# Altium schematic sheet Sheet2.SchDoc
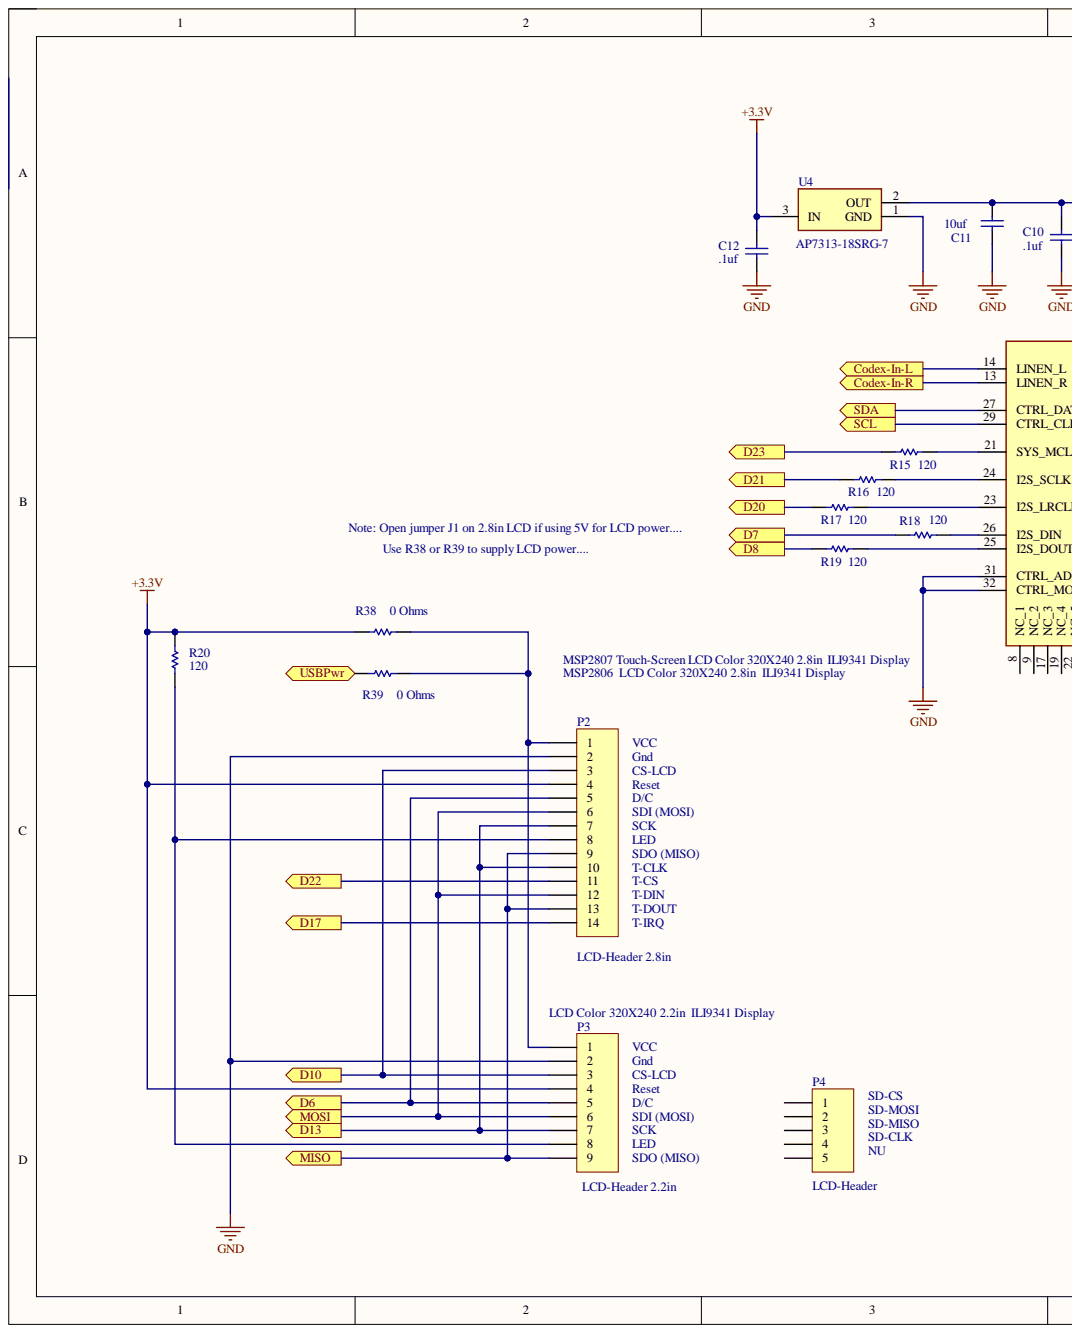
[diagram p0: Sheet2.SchDoc, left]
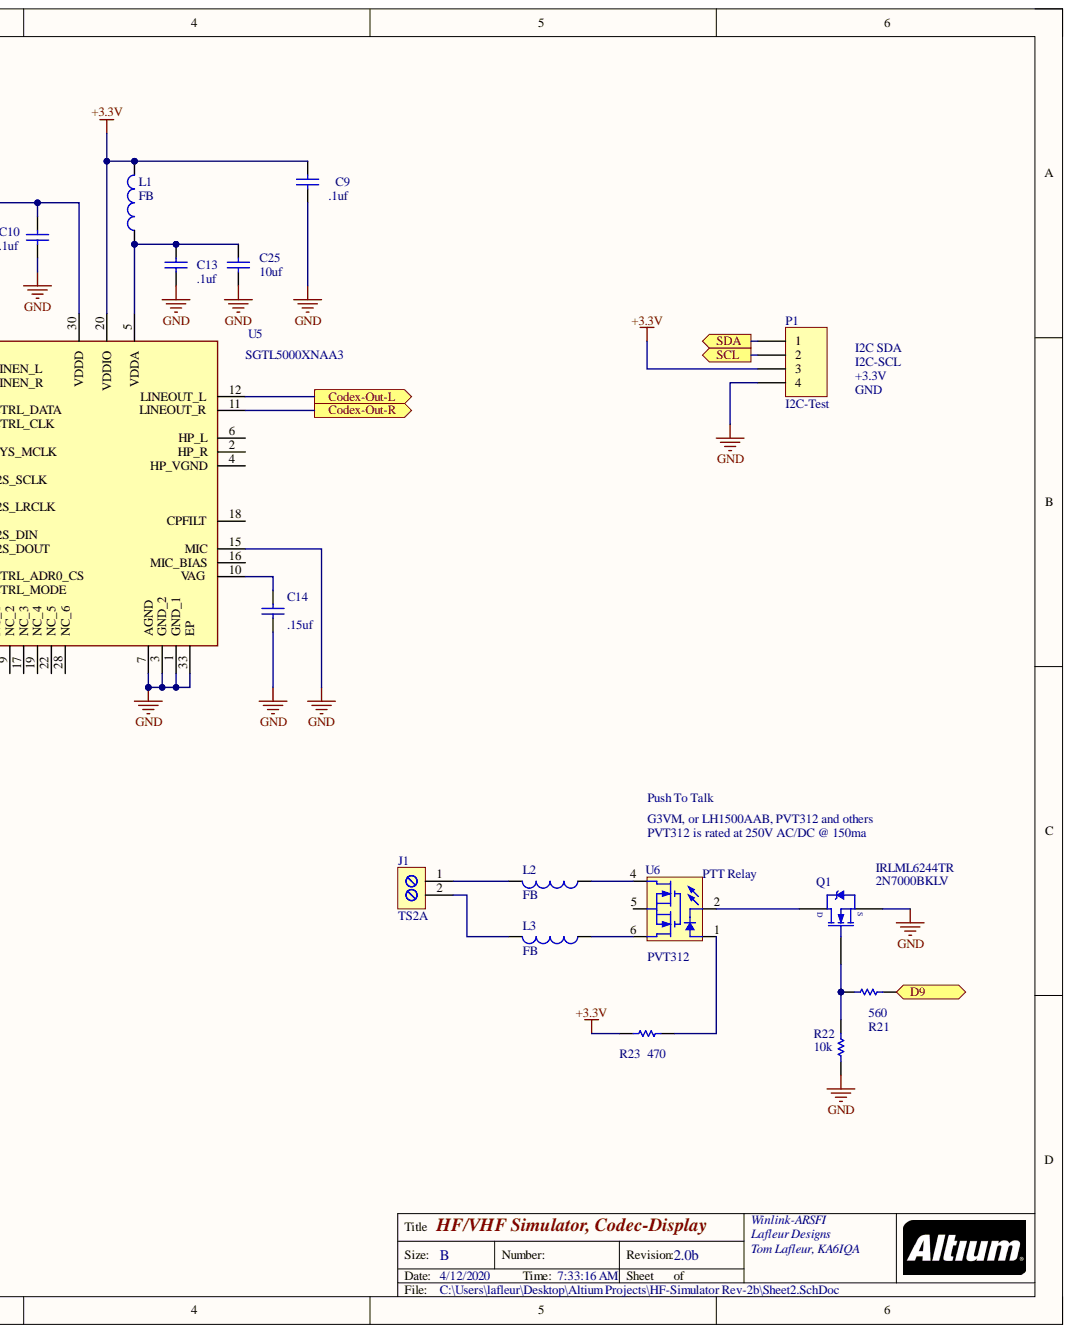
[diagram p1: Sheet2.SchDoc, right]

## Parts
C9  .1uf  [6-0805]
C10  .1uf  [6-0805]
C11  10uf  [0805]
C12  .1uf  [6-0805]
C13  .1uf  [6-0805]
C14  .15uf  [6-0805]
C25  10uf  [0805]
J1  TS2A  [TS3.81X2]
L1  FB  [6-0805]
L2  FB  [6-0805]
L3  FB  [6-0805]
P1  I2C-Test  [HDR1X4]
P2  LCD-Header 2.8in  [HDR1X14]
P3  LCD-Header 2.2in  [HDR1X9]
P4  LCD-Header  [HDR1X5]
Q1  IRLML6244TR  [micro3]
R15  120  [6-0805]
R16  120  [6-0805]
R17  120  [6-0805]
R18  120  [6-0805]
R19  120  [6-0805]
R20  120  [6-0805]
R21  560  [6-0805]
R22  10k  [6-0805]
R23  470  [6-0805]
R38  0 Ohms  [6-0805]
R39  0 Ohms  [6-0805]
U4  AP7313-18SRG-7  [SOT96P240X135-3N]
U5  SGTL5000XNAA3  [QFN50P500X500X90-33N]
U6  PVT312  [SOP254P978X342-6N]

## Ports
Codex-In-L, Codex-In-R, Codex-Out-L, Codex-Out-R, D6, D7, D8, D9, D10, D13, D17, D20, D21, D22, D23, MISO, MOSI, SCL, SDA, USBPwr

## Nets
+3.3V: C9.1 C12.1 L1.2 P1.3 P2.4 P3.4 R20.1 R23.2 R38.1 U4.3 U5.20(VDDIO)
GND: C9.2 C10.2 C11.2 C12.2 C13.2 C14.2 C25.2 P1.4 P2.2 P3.2 Q1.2 R22.2 U4.1 U5.1(GND_1) U5.3(GND_2) U5.7(AGND) U5.15(MIC) U5.31(CTRL_ADR0_CS) U5.32(CTRL_MODE) U5.33(EP)
Codex-In-L: U5.14(LINEN_L) ; port Codex-In-L
Codex-In-R: U5.13(LINEN_R) ; port Codex-In-R
Codex-Out-L: U5.12(LINEOUT_L) ; port Codex-Out-L
Codex-Out-R: U5.11(LINEOUT_R) ; port Codex-Out-R
D6: P2.5 P3.5 ; port D6
D7: R18.2 ; port D7
D8: R19.2 ; port D8
D9: R21.1 ; port D9
D10: P2.3 P3.3 ; port D10
D13: P2.7 P2.10 P3.7 ; port D13
D17: P2.14 ; port D17
D20: R17.2 ; port D20
D21: R16.2 ; port D21
D22: P2.11 ; port D22
D23: R15.2 ; port D23
MISO: P2.9 P2.13 P3.9 ; port MISO
MOSI: P2.6 P2.12 P3.6 ; port MOSI
SCL: P1.2 U5.29(CTRL_CLK) ; port SCL
SDA: P1.1 U5.27(CTRL_DATA) ; port SDA
USBPwr: R39.1 ; port USBPwr
NetC10_1: C10.1 C11.1 U4.2 U5.30(VDDD)
NetC13_1: C13.1 C25.1 L1.1 U5.5(VDDA)
NetC14_1: C14.1 U5.10(VAG)
NetJ1_1: J1.1 L2.2
NetJ1_2: J1.2 L3.2
NetL2_1: L2.1 U6.4
NetL3_1: L3.1 U6.6
NetP2_1: P2.1 P3.1 R38.2 R39.2
NetP2_8: P2.8 P3.8 R20.2
NetQ1_1: Q1.1 R21.2 R22.1
NetQ1_3: Q1.3 U6.2
NetR15_1: R15.1 U5.21(SYS_MCLK)
NetR16_1: R16.1 U5.24(I2S_SCLK)
NetR17_1: R17.1 U5.23(I2S_LRCLK)
NetR18_1: R18.1 U5.26(I2S_DIN)
NetR19_1: R19.1 U5.25(I2S_DOUT)
NetR23_1: R23.1 U6.1

Not connected: P4 1 2 3 4 5; U5 2(HP_R) 4(HP_VGND) 6(HP_L) 8(NC_1) 9(NC_2) 16(MIC_BIAS) 17(NC_3) 18(CPFILT) 19(NC_4) 22(NC_5) 28(NC_6); U6 5

## Library data of the parts
C9 C10 C12-C14 = CAP_1: Capacitor; Fitted: True; Component Kind: Standard; Library Name: N2K Project.SCHLIB
C11 C25 = CAP_2: Capacitor; Fitted: True; Component Kind: Standard; Library Name: Winmor-Demo-R2.IntLib
J1 = TS2A: Screw Terminal, 3.81mm (.15in) 2X; Component Kind: Standard; Library Name: WaterSensor_1.SCHLIB
L1-L3 = INDUCTOR: Component Kind: Standard; DatasheetDocument: RevA, 02/02; Published: 5-Jan-2004; Publisher: Altium Limited
  L2 L3: Library Name: N2K Sensor Board_3.SCHLIB
  L1: Library Name: ARDOP-Modem Rev1.SCHLIB
P1 = Header 4: Header, 4-Pin; Component Kind: Standard; LatestRevisionDate: 17-Jul-2002; LatestRevisionNote: Re-released for DXP Platform.; Library Name: Miscellaneous Connectors.IntLib; Signal Integrity: Connector; Publisher: Altium Limited
P2 = Header 14: Header, 14-Pin; Component Kind: Standard; LatestRevisionDate: 17-Jul-2002; LatestRevisionNote: Re-released for DXP Platform.; Library Name: Miscellaneous Connectors.IntLib; Signal Integrity: Connector; Publisher: Altium Limited
P3 = Header 9: Header, 9-Pin; Component Kind: Standard; LatestRevisionDate: 17-Jul-2002; LatestRevisionNote: Re-released for DXP Platform.; Library Name: Miscellaneous Connectors.IntLib; Signal Integrity: Connector; Publisher: Altium Limited
P4 = Header 5: Header, 5-Pin; Component Kind: Standard; LatestRevisionDate: 17-Jul-2002; LatestRevisionNote: Re-released for DXP Platform.; Library Name: Miscellaneous Connectors.IntLib; Signal Integrity: Connector; Publisher: Altium Limited
Q1 = IRLML6244TR: HEXFET N-Channel; Component Kind: Standard; Library Name: ARDOP-Modem Rev1_1.SCHLIB
R15-R23 R38 R39 = ERJ8EN: Precision Thick Film Chip Resistor, 10 Ohm to 2.2M Ohm Range, 1% Tolerance, 1206 Size, 0.125 W; Code_IPC: 3216 [1206]; Component Kind: Standard; ComponentLink1Description: Manufacturer Link; ComponentLink1URL: http://www.panasonic.com/industrial/components/resistive_products.htm; ComponentLink2Description: Datasheet; ComponentLink2URL: http://www.panasonic.com/industrial/components/pdf/AOA0000CE2.pdf; LatestRevisionDate: 19-Mar-2004; LatestRevisionNote: Silkscreen size reduced.; PackageDocument: Mar-2003; PackageReference: 8-1206; Published: 17-Dec-2003; Library Name: Winmor-Demo-R1.IntLib; Publisher: Altium Limited
  R20-R23 R38 R39: Signal Integrity: Res; Simulation: RESISTOR
U4 = AP7313-30SRG-7: Integrated Circuit; Manufacturer_Name: Diodes Inc.; Component Kind: Standard; Datasheet Link: https://www.diodes.com/assets/Datasheets/AP7313.pdf; Height: 1.35mm; Manufacturer_Part_Number: AP7313-30SRG-7; Mouser Part Number: 621-AP7313-30SRG-7; Mouser Price/Stock: https://www.mouser.com/Search/Refine.aspx?Keyword=621-AP7313-30SRG-7
U5 = SGTL5000XNAA3: Integrated Circuit; Manufacturer_Name: Nexperia; Component Kind: Standard; Datasheet Link: https://www.nxp.com/docs/en/data-sheet/SGTL5000.pdf; Height: 0.9mm; Manufacturer_Part_Number: SGTL5000XNAA3; Mouser Part Number: 841-SGTL5000XNAA3; Mouser Price/Stock: https://www.mouser.com/Search/Refine.aspx?Keyword=841-SGTL5000XNAA3; RS Part Number: 8212245P; RS Price/Stock: http://uk.rs-online.com/web/p/products/8212245P; Library Name: HF-Simulator Rev-1.SCHLIB
U6 = PVT312: SS Relay, 250V 200ma; Component Kind: Standard; Supplier 1: Allied; Supplier Part Number 1: 70017910; Library Name: Winmor-Demo-R1.SCHLIB
